# Altium schematic sheet Peripherals.SchDoc(Peripherals)
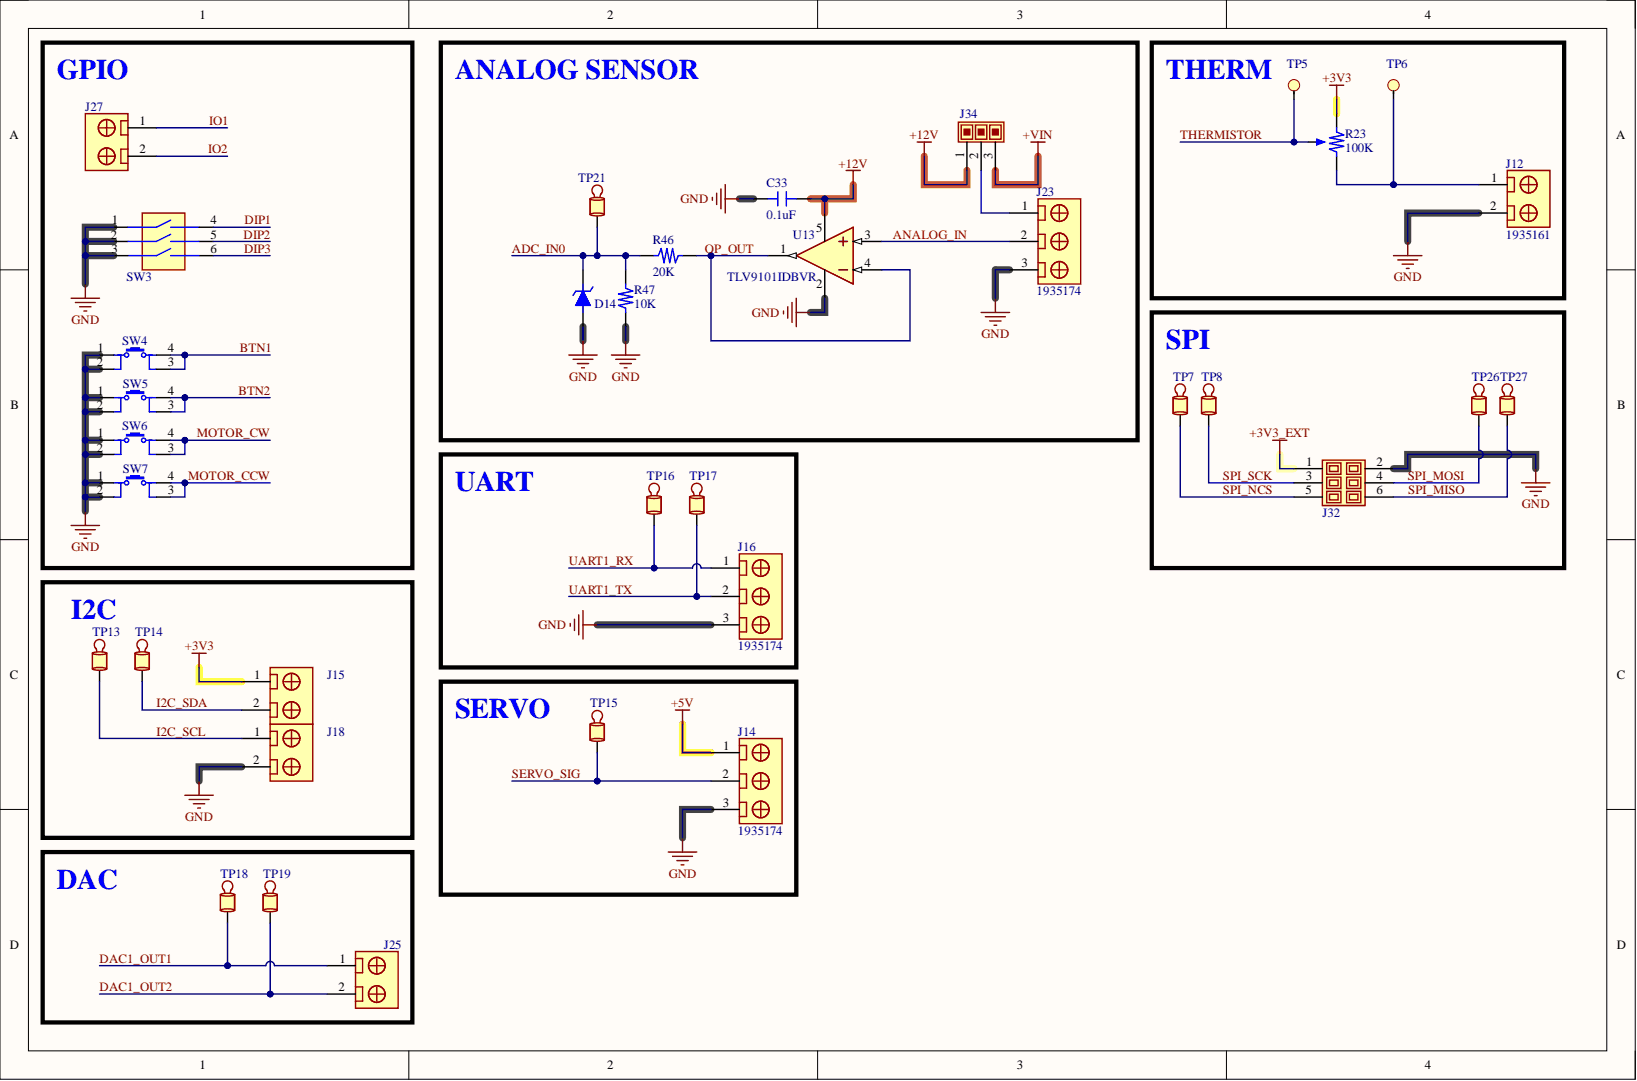
[diagram p0: Peripherals.SchDoc]

## Parts
C33  value 0.1uF  [CAP0603]  (hidden comment: GRM188R72A104KA35D)
D14  BZT52C3V3-7-F  [SOD123]  (value not drawn)
J12  1935161  [1935161]
J14  1935174  [1935174]
J15  1935161  [1935161]  (value not drawn)
J16  1935174  [1935174]
J18  1935161  [1935161]  (value not drawn)
J23  1935174  [1935174]
J25  1935161  [1935161]  (value not drawn)
J27  1935161  [1935161]  (value not drawn)
J32  PPTC032LFBN-RC  [3PX2_2.54MM HEADER]  (value not drawn)
J34  68001-203HLF  [3P-2.54mm-MalePins]  (value not drawn)
R23  value 100K  [Potentiometer-3296W]  (hidden comment: 3296W-1-104LF)
R46  value 20K  [RES0603]  (hidden comment: RC0603FR-0720KL)
R47  value 10K  [RES0603]  (hidden comment: RC0603FR-0710KL)
SW3  219-3LPSTR  [219-3LPSTR]  (value not drawn)
SW4  PTS647SN38SMTR2 LFS  [PTS647SN38SMTR2 LFS]  (value not drawn)
SW5  PTS647SN38SMTR2 LFS  [PTS647SN38SMTR2 LFS]  (value not drawn)
SW6  PTS647SN38SMTR2 LFS  [PTS647SN38SMTR2 LFS]  (value not drawn)
SW7  PTS647SN38SMTR2 LFS  [PTS647SN38SMTR2 LFS]  (value not drawn)
TP5  TP-0.5x0.9MM  [TP-0.5x0.9MM]  (value not drawn)  (no BOM)
TP6  TP-0.5x0.9MM  [TP-0.5x0.9MM]  (value not drawn)  (no BOM)
TP7  5001  [5001]  (value not drawn)
TP8  5001  [5001]  (value not drawn)
TP13  5001  [5001]  (value not drawn)
TP14  5001  [5001]  (value not drawn)
TP15  5001  [5001]  (value not drawn)
TP16  5001  [5001]  (value not drawn)
TP17  5001  [5001]  (value not drawn)
TP18  5001  [5001]  (value not drawn)
TP19  5001  [5001]  (value not drawn)
TP21  5001  [5001]  (value not drawn)
TP26  5001  [5001]  (value not drawn)
TP27  5001  [5001]  (value not drawn)
U13  TLV9101IDBVR  [SOT23-5]

## Nets
+3V3: J15.1 R23.3
+3V3_EXT: J32.1
+5V: J14.1
+12V: C33.1 J34.1 U13.5
+VIN: J34.3
GND: C33.2 D14.A J12.2 J14.3 J16.3 J18.2 J23.3 J32.2 R47.2 SW3.1 SW3.2 SW3.3 SW4.1 SW4.2 SW5.1 SW5.2 SW6.1 SW6.2 SW7.1 SW7.2 U13.2
ADC_IN0: D14.K R46.2 R47.1 TP21.1
ANALOG_IN: J23.2 U13.3
BTN1: SW4.3 SW4.4
BTN2: SW5.3 SW5.4
DAC1_OUT1: J25.1 TP18.1
DAC1_OUT2: J25.2 TP19.1
DIP1: SW3.4
DIP2: SW3.5
DIP3: SW3.6
I2C_SCL: J18.1 TP13.1
I2C_SDA: J15.2 TP14.1
IO1: J27.1
IO2: J27.2
MOTOR_CCW: SW7.3 SW7.4
MOTOR_CW: SW6.3 SW6.4
OP_OUT: R46.1 U13.1 U13.4
SERVO_SIG: J14.2 TP15.1
SPI_MISO: J32.6 TP27.1
SPI_MOSI: J32.4 TP26.1
SPI_NCS: J32.5 TP7.1
SPI_SCK: J32.3 TP8.1
THERMISTOR: R23.2 TP5.1
UART1_RX: J16.1 TP16.1
UART1_TX: J16.2 TP17.1
NetJ12_1: J12.1 R23.1 TP6.1
NetJ23_1: J23.1 J34.2

## Library data of the parts
C33 = GRM188R72A104KA35D: 0.1 µF ±10% 100V Ceramic Capacitor X7R 0603 (1608 Metric); Manufacturer: Murata Electronics; Component Kind: Standard; ComponentLink1Description: Datasheet; ComponentLink1URL: https://search.murata.co.jp/Ceramy/image/img/A01X/G101/ENG/GRM188R72A104KA35-01.pdf; ComponentLink2Description: DigiKey Link; ComponentLink2URL: https://www.digikey.com/en/products/detail/murata-electronics/GRM188R72A104KA35D/702549; ComponentLink3Description: LCSC Link; ComponentLink3URL: https://lcsc.com/product-detail/Multilayer-Ceramic-Capacitors-MLCC-SMD-SMT_muRata-GRM188R72A104KA35D_C77058.html?s_z=n_GRM188R72A104KA35D; DigiKey PN: 490-3285-1-ND; LCSC PN: C77058; Library Name: 01-Capacitors_0603.IntLib; MPN: GRM188R72A104KA35D; Package: 0603; Temp Coefficient: X7R; Tolerance: ±10%; Voltage Rating: 100V
D14 = BZT52C3V3-7-F: DIODE ZENER 3.3V 500MW SOD123; Manufacturer: Diodes Incorporated; Component Kind: Standard; ComponentLink1Description: Datasheet; ComponentLink1URL: https://www.diodes.com/assets/Datasheets/ds18004.pdf; ComponentLink2Description: DigiKey Link; ComponentLink2URL: https://www.digikey.co.il/en/products/detail/diodes-incorporated/BZT52C3V3-7-F/717734; ComponentLink3Description: LCSC Link; ComponentLink3URL: https://lcsc.com/product-detail/Zener-Diodes_Diodes-Incorporated-BZT52C3V3-7-F_C151564.html?s_z=n_BZT52C3V3-7-F; DigiKey PN: BZT52C3V3-FDICT-ND; LCSC PN: C151564; Library Name: 02-Diodes.IntLib; MPN: BZT52C3V3-7-F; Package: SOD123
J12 J15 J18 J25 J27 = 1935161: TB 5.00mm 2POS TH 18A, 300V, 12-26 AWG Wire; Manufacturer: Phoenix Contact; Component Kind: Standard; ComponentLink1Description: Datasheet; ComponentLink1URL: https://mm.digikey.com/Volume0/opasdata/d220001/medias/docus/909/1935161.pdf; ComponentLink2Description: DigiKey Link; ComponentLink2URL: https://www.digikey.com/en/products/detail/phoenix-contact/1935161/568614; ComponentLink3Description: LCSC Link; ComponentLink3URL: https://www.lcsc.com/product-detail/C3819953.html?s_z=n_1935161; DigiKey PN: 277-1667-ND; LCSC PN: C3819953; Library Name: 11-Connectors_5.00mm.IntLib; MPN: 1935161; Series: COMBICON PT
J14 J16 J23 = 1935174: TB 5.00mm 3POS TH 18A, 300V, 12-26 AWG Wire; Manufacturer: Phoenix Contact; Component Kind: Standard; ComponentLink1Description: Datasheet; ComponentLink1URL: https://mm.digikey.com/Volume0/opasdata/d220001/medias/docus/1123/1935174.pdf; ComponentLink2Description: DigiKey Link; ComponentLink2URL: https://www.digikey.com/en/products/detail/phoenix-contact/1935174/568615; ComponentLink3Description: LCSC Link; ComponentLink3URL: https://www.lcsc.com/product-detail/C3816891.html; DigiKey PN: 277-1578-ND; LCSC PN: C3816891; Library Name: 11-Connectors_5.00mm.IntLib; MPN: 1935174; Series: COMBICON PT
J32 = PPTC032LFBN-RC: 6 Position Header Connector 0.100" (2.54mm) Through Hole Tin; Manufacturer: Sullins Connector Solutions; Component Kind: Standard; ComponentLink1Description: Datasheet; ComponentLink1URL: https://mm.digikey.com/Volume0/opasdata/d220001/medias/docus/937/Female_Headers.100_DS.pdf; ComponentLink2Description: DigiKey Link; ComponentLink2URL: https://www.digikey.com/en/products/detail/sullins-connector-solutions/PPTC032LFBN-RC/810210; ComponentLink3Description: LCSC Link; ComponentLink3URL: https://www.lcsc.com/product-detail/C2897404.html; DigiKey PN: S7071-ND; LCSC ALT PN: C2897404; Library Name: 11-Connectors_2.54mm.IntLib; MPN: PPTC032LFBN-RC
J34 = 68001-203HLF: Connector Header Through Hole 3 position 0.100" (2.54mm); Manufacturer: Amphenol ICC (FCI); Component Kind: Standard; ComponentLink1Description: Datasheet; ComponentLink1URL: https://www.waldom.com/upload/parts/datasheets/mf-fci/fci-68001-203hlf.pdf; ComponentLink2Description: DigiKey Link; ComponentLink2URL: https://www.digikey.co.il/en/products/detail/amphenol-cs-fci/68001-203HLF/2023312?s=N4IgTCBcDaIGwA4AMSCMBaMSDMAJAMgGIgC6AvkA; ComponentLink3Description: LCSC Link; ComponentLink3URL: https://lcsc.com/product-detail/Pin-Headers_Amphenol-ICC-68001-203HLF_C5346215.html; DigiKey PN: 609-3470-ND; LCSC PN: C5346215; Library Name: 11-Connectors_2.54mm.IntLib; MPN: 68001-203HLF
R23 = 3296W-1-104LF: TRIMMER 100K OHM 0.5W PC PIN TOP; Manufacturer: Bourns Inc.; Component Kind: Standard; ComponentLink1Description: Datasheet; ComponentLink1URL: https://www.bourns.com/docs/product-datasheets/3296.pdf; ComponentLink2Description: DigiKey Link; ComponentLink2URL: https://www.digikey.com/en/products/detail/bourns-inc/3296W-1-104LF/1088046?s=N4IgTCBcDaIMxgJwDYDqBaAjFgDAFgBkAxEAXQF8g; ComponentLink3Description: LCSC Link; ComponentLink3URL: https://www.lcsc.com/product-detail/C48084.html; DigiKey PN: 3296W-104LF-ND; LCSC PN: C48084; MPN: 3296W-1-104LF; Library Name: 00-Resistors_Potentiometers.IntLib
R46 = RC0603FR-0720KL: 20 kOhms ±1% 0.1W, 1/10W Chip Resistor 0603 (1608 Metric) Moisture Resistant Thick Film; Manufacturer: YAGEO; Component Kind: Standard; ComponentLink1Description: Datasheet; ComponentLink1URL: https://www.yageogroup.com/content/datasheet/asset/file/PYU-RC_GROUP_51_ROHS_L; ComponentLink2Description: DigiKey Link; ComponentLink2URL: https://www.digikey.com/en/products/detail/yageo/RC0603FR-0720KL/727040?s=N4IgTCBcDaIEoGEAMA2JBmAYnAtEg7GEgNIAyIAugL5A; ComponentLink3Description: LCSC Link; ComponentLink3URL: https://www.lcsc.com/product-detail/C105575.html?s_z=n_RC0603FR-0720KL; DigiKey PN: 311-20.0KHRCT-ND; LCSC PN: C105575; Library Name: 00-Resistors_0603.IntLib; MPN: RC0603FR-0720KL; Package: 0603
R47 = RC0603FR-0710KL: 10 kOhms ±1% 0.1W, 1/10W Chip Resistor 0603 (1608 Metric) Moisture Resistant Thick Film; Manufacturer: YAGEO; Component Kind: Standard; ComponentLink1Description: Datasheet; ComponentLink1URL: https://www.yageo.com/upload/media/product/products/datasheet/rchip/PYu-RC_Group_51_RoHS_L_12.pdf; ComponentLink2Description: DigiKey Link; ComponentLink2URL: https://www.digikey.com/en/products/detail/yageo/RC0603FR-0710KL/726880?s=N4IgTCBcDaIEoGEAMA2JBmAYnAtEg7AIxIDSAMiALoC%2BQA; ComponentLink3Description: LCSC Link; ComponentLink3URL: https://lcsc.com/product-detail/Chip-Resistor-Surface-Mount_YAGEO-RC0603FR-0710KL_C98220.html?s_z=n_RC0603FR-0710KL; DigiKey PN: 311-10.0KHRCT-ND; LCSC PN: C98220; Library Name: 00-Resistors_0603.IntLib; MPN: RC0603FR-0710KL; Package: 0603
SW3 = 219-3LPSTR: SWITCH SLIDE DIP SPST 0.1A 20V; Manufacturer: CTS Electrocomponents; Pitch: 2.54mm; Component Kind: Standard; ComponentLink1Description: Datasheet; ComponentLink1URL: https://www.ctscorp.com/Files/DataSheets/Switches/DIP-Switches/CTS-Switches-DIP-219-Series-Datasheet.pdf; ComponentLink2Description: DigiKey Link; ComponentLink2URL: https://www.digikey.com/en/products/detail/cts-electrocomponents/219-3LPSTR/2734694; ComponentLink3Description: LCSC Link; ComponentLink3URL: https://lcsc.com/product-detail/DIP-Switches_CTS-Electronic-Components-219-3LPSTR_C2961925.html?s_z=n_219-3LPSTR; DigiKey PN: 119-219-3LPSTRCT-ND; LCSC PN: C2961925; Library Name: 10-Mechanical.IntLib; MPN: 219-3LPSTR
SW4-SW7 = PTS647SN38SMTR2 LFS: SWITCH TACTILE SPST-NO 0.05A 12V; Manufacturer: C&K; MPN: PTS815SJM250SMTRLFS; Component Kind: Standard; ComponentLink1Description: Datasheet; ComponentLink1URL: https://www.ckswitches.com/media/2567/pts647.pdf; ComponentLink2Description: DigiKey Link; ComponentLink2URL: https://www.digikey.com/en/products/detail/c-k/PTS647SN38SMTR2-LFS/9649860; ComponentLink3Description: LCSC Link; ComponentLink3URL: https://www.lcsc.com/product-detail/C2799279.html; ComponentLink4Description: LCSC Alternative PN; DigiKey PN: PTS647SN38SMTR2LFSCT-ND; LCSC Alternative PN: C778166; LCSC PN: C2799279; Library Name: 10-Mechanical.IntLib; Package: 4.5x4.5mm
TP7 TP8 TP13-TP19 TP21 TP26 TP27 = 5001: TEST POINT RING, BACK - TH; Manufacturer: Keystone Electronics; Component Kind: Standard; ComponentLink1Description: Datasheet; ComponentLink1URL: https://www.keyelco.com/userAssets/file/M65p56.pdf; ComponentLink2Description: DigiKey Link; ComponentLink2URL: https://www.digikey.com/en/products/detail/keystone-electronics/5001/255327; ComponentLink3Description: LCSC Link; ComponentLink3URL: https://www.lcsc.com/product-detail/C238122.html; DigiKey PN: 36-5001-ND; LCSC PN: C238122; Library Name: 09-TestPoints.IntLib; MPN: 5001
U13 = TLV9101IDBVR: Standard (General Purpose) Amplifier 1 Circuit Rail-to-Rail SOT-23-5, VIN 2.7V - 16V; Manufacturer: Texas Instruments; Component Kind: Standard; ComponentLink1Description: Datasheet; ComponentLink1URL: https://www.ti.com/lit/ds/symlink/tlv9101.pdf; ComponentLink2Description: DigiKey Link; ComponentLink2URL: https://www.digikey.com/en/products/detail/texas-instruments/TLV9101IDBVR/12165187?s=N4IgTCBcDaICoBkBqBOAjABjQSQCICEkAlEAXQF8g; ComponentLink3Description: LCSC Link; ComponentLink3URL: https://www.lcsc.com/product-detail/C4368903.html?s_z=n_TLV9101; DigiKey PN: 296-TLV9101IDBVRCT-ND; LCSC PN: C4368903; Library Name: 13-Op_Amps.IntLib; MPN: TLV9101IDBVR; Package: SOT23-5
TP5 TP6 = TP-0.5x0.9MM: Description: TP-0.5x0.9MM; Library Name: 09-TestPoints.IntLib
